# Altium schematic sheet CHARGER.SchDoc
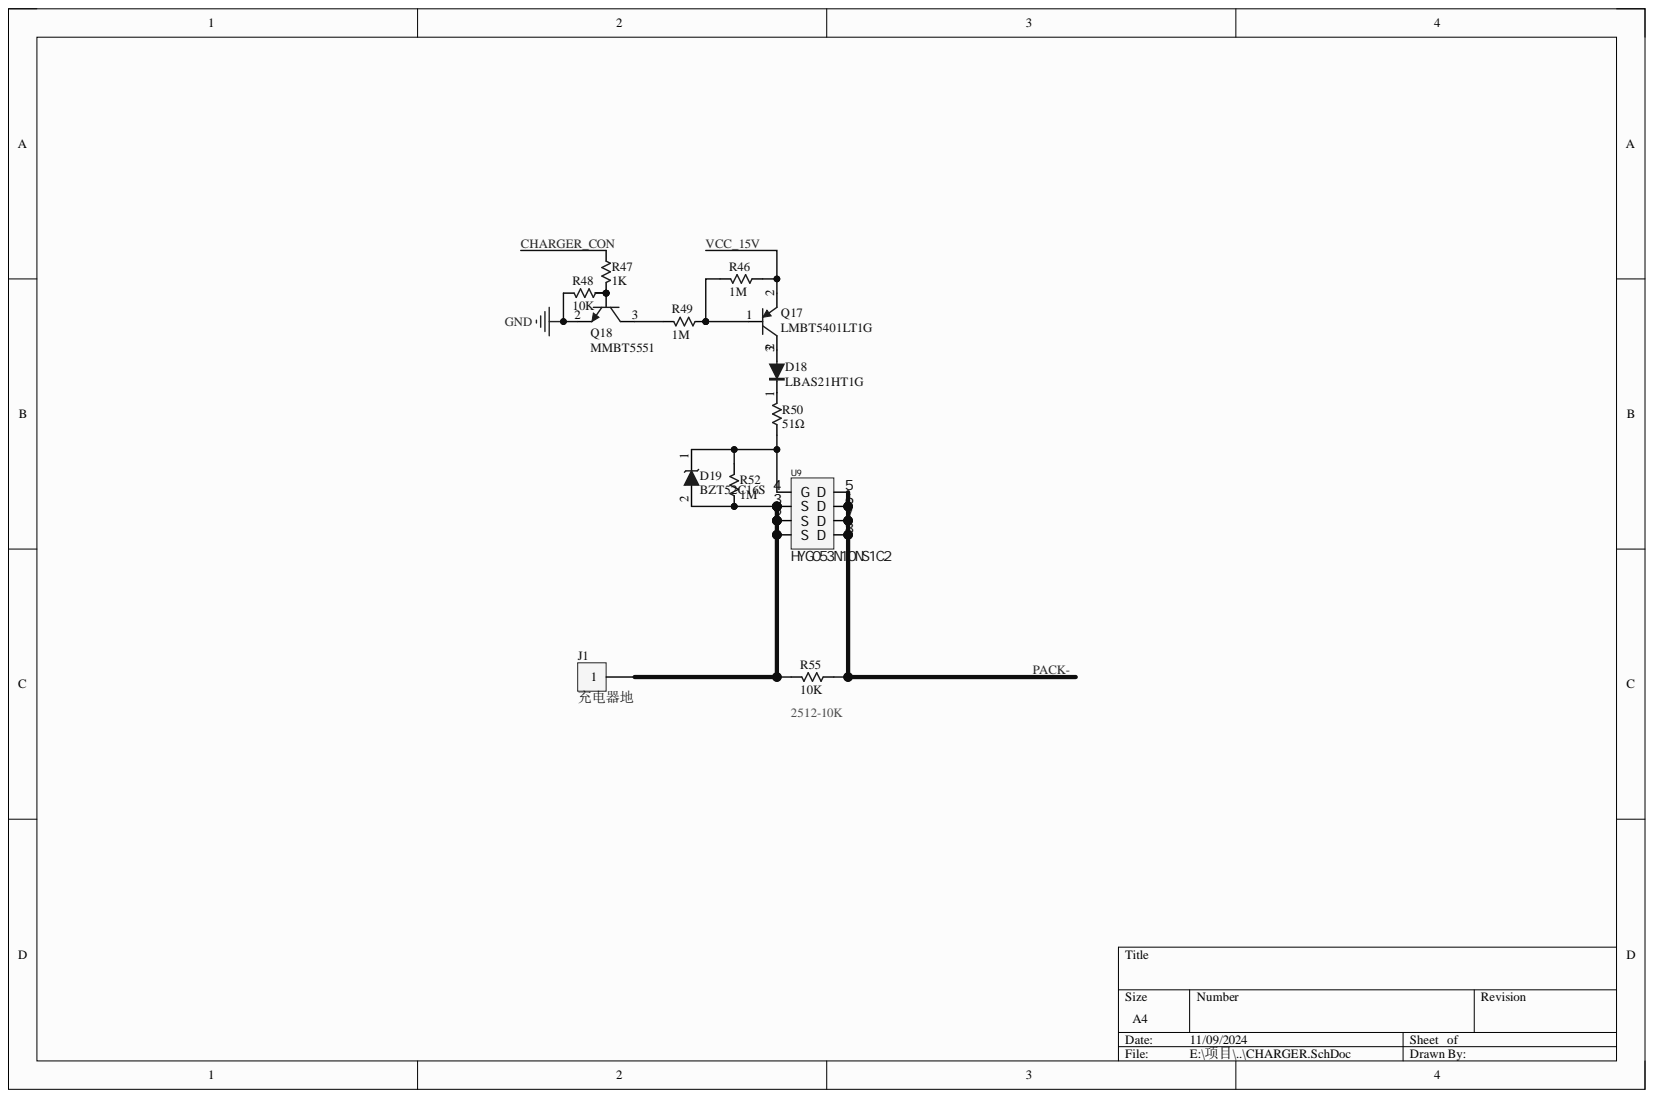
[diagram p0: CHARGER.SchDoc]

## Parts
D18  LBAS21HT1G  [SOD-323]
D19  BZT52C16S  [SOD-323]
J1  充电器地  [PAD 7*7]
Q17  LMBT5401LT1G  [SOT-23-3]
Q18  MMBT5551  [SOT-23-3]
R46  1M  [0603_R]
R47  1K  [0603_R]
R48  10K  [0603_R]
R49  1M  [0603_R]
R50  51Ω  [0603_R]
R52  1M  [0603_R]
R55  10K  [2512_R]
U9  HYG053N10NS1C2  [DFN5x6]

## Nets
GND: Q18.2 R48.1
CHARGER_CON: R47.1
PACK-: R55.1 U9.5(D) U9.6(D) U9.7(D) U9.8(D)
VCC_15V: Q17.2 R46.2
NetD18_1: D18.1 R50.1
NetD18_2: D18.2 Q17.3
NetD19_1: D19.1 R50.2 R52.2 U9.4(G)
NetD19_2: D19.2 J1.1 R52.1 R55.2 U9.1(S) U9.2(S) U9.3(S)
NetQ17_1: Q17.1 R46.1 R49.2
NetQ18_1: Q18.1 R47.2 R48.2
NetQ18_3: Q18.3 R49.1

## Library data of the parts
D18 = 1N4148W: Component Kind: Standard; Library Name: DVC1124_20S.SchLib
J1 = 1P: Component Kind: Standard; Library Name: UP-ROBOT Devices.SchLib
Q17 = PNP: Component Kind: Standard; Library Name: Three_Axis_Arm_Control_V1.1.SchLib
Q18 = NPN: Component Kind: Standard; Library Name: Three_Axis_Arm_Control_V1.1.SchLib
R46-R50 R52 R55 = Res: Resistor; Component Kind: Standard; LatestRevisionDate: 17-Jul-2002; LatestRevisionNote: Re-released for DXP Platform.; PackageReference: AXIAL-0.3; Published: 8-Jun-2000; Signal Integrity: Res; Simulation: RESISTOR; Library Name: UP-ROBOT Devices.SchLib; Publisher: Altium Limited
  R46 R49 R52: Value: 1M
  R47: Value: 1K
  R48 R55: Value: 10K
  R50: Value: 51Ω
U9 = HYG053N10NS1C2: Manufacturer: HL(富海微); Add into BOM: yes; Continuous Drain Current (Id): 80A; Device: 80N02F; Drain Source On Resistance (RDS(on)@Vgs,Id): 3.5mΩ; Drain Source Voltage (Vdss): 20V; Gate Threshold Voltage (Vgs(th)@Id): 1.1V; Component Kind: Standard; Convert to PCB: yes; Datasheet: https://atta.szlcsc.com/upload/public/pdf/source/20230704/CD294B21471143D9902F06CC9E3EE128.pdf; LCSC Part Name: 耐压:20V 电流:80A N沟道; Library Name: DVC1124_20S_MCU_MOS.SchLib; link: https://atta.szlcsc.com/upload/public/pdf/source/20230704/CD294B21471143D9902F06CC9E3EE128.pdf; Manufacturer Part: 80N02F; Name: 80N02F; Supplier: LCSC; Supplier Footprint: DFN5x6; Supplier Part: C7437081; Symbol: 80N02F; Type: N沟道
D19 = BZT52C16S: Component Kind: Standard; Library Name: DVC1124_20S.SchLib
